# Altium schematic sheet TopLevel.SchDoc(TopLevel)
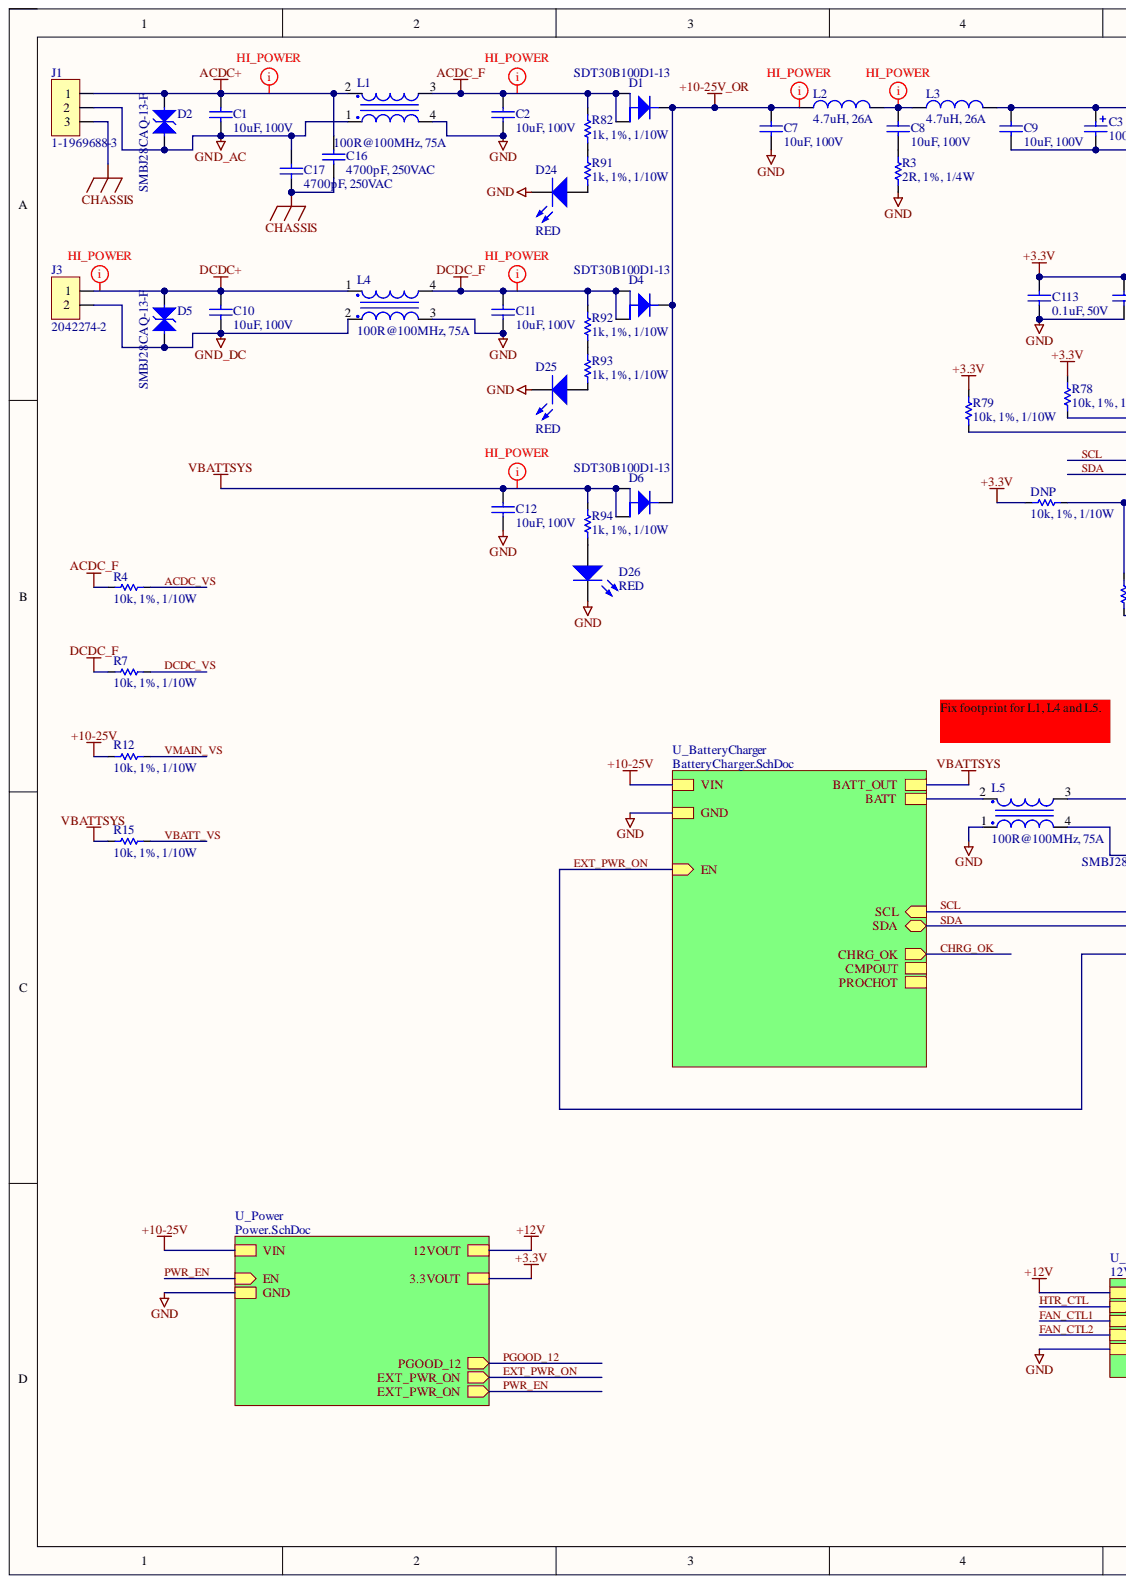
[diagram p0: TopLevel.SchDoc, left]
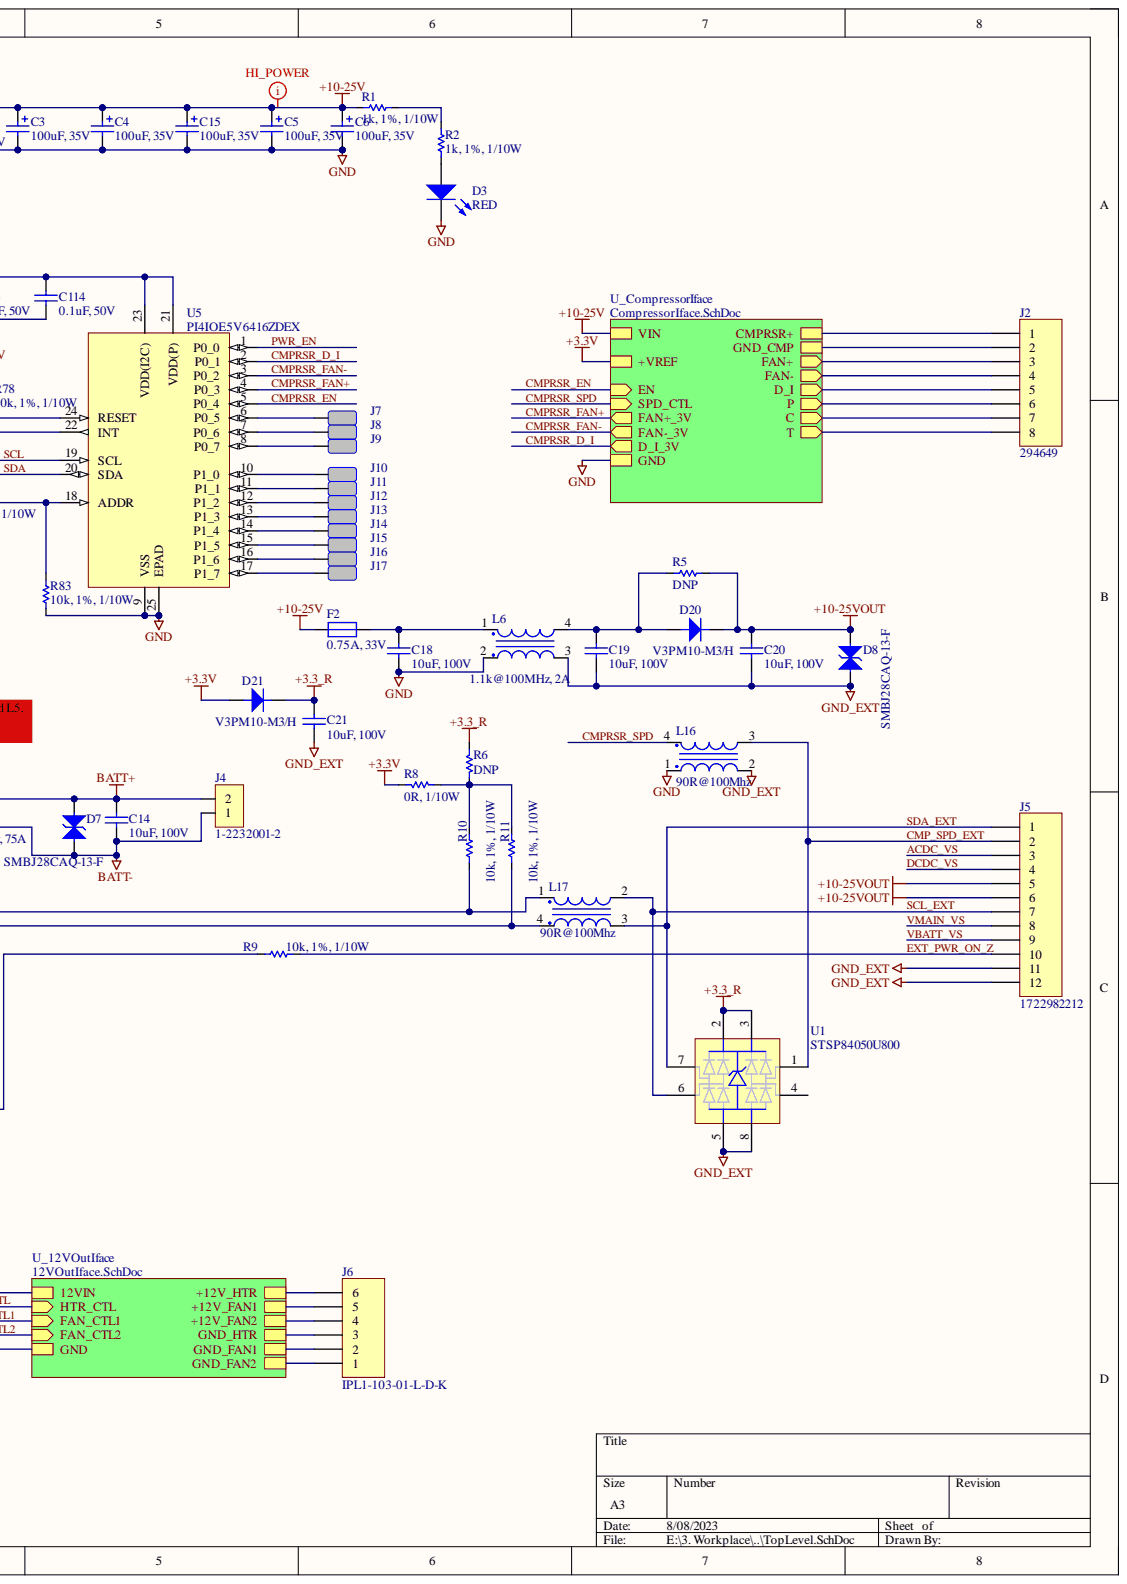
[diagram p1: TopLevel.SchDoc, right]

## Parts
C1  10uF, 100V  [1210]
C2  10uF, 100V  [1210]
C3  100uF, 35V  [CAPAE7866X80L]
C4  100uF, 35V  [CAPAE7866X80L]
C5  100uF, 35V  [CAPAE7866X80L]
C6  100uF, 35V  [CAPAE7866X80L]
C7  10uF, 100V  [1210]
C8  10uF, 100V  [1210]
C9  10uF, 100V  [1210]
C10  10uF, 100V  [1210]
C11  10uF, 100V  [1210]
C12  10uF, 100V  [1210]
C14  10uF, 100V  [1210]
C15  100uF, 35V  [CAPAE7866X80L]
C16  4700pF, 250VAC  [2220-2.5_CAP]
C17  4700pF, 250VAC  [2220-2.5_CAP]
C18  10uF, 100V  [1210]
C19  10uF, 100V  [1210]
C20  10uF, 100V  [1210]
C21  10uF, 100V  [1210]
C113  0.1uF, 50V  [0603]
C114  0.1uF, 50V  [0603]
D1  SDT30B100D1-13  [DPAK]
D2  SMBJ28CAQ-13-F  [SMB]
D3  RED  [0603_DIODE_K1A2]
D4  SDT30B100D1-13  [DPAK]
D5  SMBJ28CAQ-13-F  [SMB]
D6  SDT30B100D1-13  [DPAK]
D7  SMBJ28CAQ-13-F  [SMB]
D8  SMBJ28CAQ-13-F  [SMB]
D20  V3PM10-M3/H  [SMP]
D21  V3PM10-M3/H  [SMP]
D24  RED  [0603_DIODE_K1A2]
D25  RED  [0603_DIODE_K1A2]
D26  RED  [0603_DIODE_K1A2]
DNP  10k, 1%, 1/10W  [0603]
F2  0.75A, 33V  [1812_CAP]
J1  1-1969688-3  [CONN_TE_1-1969688-3]
J2  294649  [CONN_TE_294649]
J3  2042274-2  [CONN_TE_2042274-2]
J4  1-2232001-2  [CONN_TE_1-2232001-2]
J5  1722982212  [CONN_MOLEX_1722982212]
J6  IPL1-103-01-L-D-K  [CONN_SAMTEC_IPL1-103-XX-X-D]
J7  1.5x3.5  [PAD_15_35]  (value not drawn)  (no BOM)
J8  1.5x3.5  [PAD_15_35]  (value not drawn)  (no BOM)
J9  1.5x3.5  [PAD_15_35]  (value not drawn)  (no BOM)
J10  1.5x3.5  [PAD_15_35]  (value not drawn)  (no BOM)
J11  1.5x3.5  [PAD_15_35]  (value not drawn)  (no BOM)
J12  1.5x3.5  [PAD_15_35]  (value not drawn)  (no BOM)
J13  1.5x3.5  [PAD_15_35]  (value not drawn)  (no BOM)
J14  1.5x3.5  [PAD_15_35]  (value not drawn)  (no BOM)
J15  1.5x3.5  [PAD_15_35]  (value not drawn)  (no BOM)
J16  1.5x3.5  [PAD_15_35]  (value not drawn)  (no BOM)
J17  1.5x3.5  [PAD_15_35]  (value not drawn)  (no BOM)
L1  100R@100MHz, 75A  [CHOKE_TH_13.72x10.41x10.52_6.35x5.28_3.07x0.97]
L2  4.7uH, 26A  [INDM178169X70N]
L3  4.7uH, 26A  [INDM178169X70N]
L4  100R@100MHz, 75A  [CHOKE_TH_13.72x10.41x10.52_6.35x5.28_3.07x0.97]
L5  100R@100MHz, 75A  [CHOKE_TH_13.72x10.41x10.52_6.35x5.28_3.07x0.97]
L6  1.1k@100MHz, 2A  [PLCC440P435X370X235-4L]
L16  90R@100Mhz  [BOURNS_SRF2012]
L17  90R@100Mhz  [BOURNS_SRF2012]
R1  1k, 1%, 1/10W  [0603]
R2  1k, 1%, 1/10W  [0603]
R3  2R, 1%, 1/4W  [1206]
R4  10k, 1%, 1/10W  [0603]
R5  DNP  [0805]
R6  DNP  [0603]
R7  10k, 1%, 1/10W  [0603]
R8  0R, 1/10W  [0603]
R9  10k, 1%, 1/10W  [0603]
R10  10k, 1%, 1/10W  [0603]
R11  10k, 1%, 1/10W  [0603]
R12  10k, 1%, 1/10W  [0603]
R15  10k, 1%, 1/10W  [0603]
R78  10k, 1%, 1/10W  [0603]
R79  10k, 1%, 1/10W  [0603]
R82  1k, 1%, 1/10W  [0603]
R83  10k, 1%, 1/10W  [0603]
R91  1k, 1%, 1/10W  [0603]
R92  1k, 1%, 1/10W  [0603]
R93  1k, 1%, 1/10W  [0603]
R94  1k, 1%, 1/10W  [0603]
U1  STSP84050U800  [SOIC127P600X163-8N]
U5  PI4IOE5V6416ZDEX  [QFN50P400X400X90_HS-25L]

## Nets
+3.3_R: C21.2 D21.2 R6.2 U1.2 U1.3
+3.3V: C113.1 C114.1 D21.1 DNP.1 R8.1 R78.1 R79.1 U5.21(VDD(P)) U5.23(VDD(I2C))
+10-25V: C3.1 C4.1 C5.1 C6.1 C9.2 C15.1 F2.2 L3.2 R1.2 R12.2
+10-25V_OR: C7.2 D1.2 D4.2 D6.2 L2.1
+10-25VOUT: C20.2 D8.2 D20.2 J5.5 J5.6 R5.2
ACDC+: C1.2 C16.2 D2.2 J1.1 L1.2
ACDC_F: C2.2 D1.1 D1.3 L1.3 R4.2 R82.1
BATT+: C14.2 D7.2 J4.2 L5.3
BATT-: C14.1 D7.1 J4.1 L5.4
CHASSIS: C16.1 C17.1 J1.3
DCDC+: C10.2 D5.2 J3.1 L4.1
DCDC_F: C11.2 D4.1 D4.3 L4.4 R7.2 R92.1
GND: C2.1 C3.2 C4.2 C5.2 C6.2 C7.1 C9.1 C11.1 C12.1 C15.2 C18.1 C113.2 C114.2 D3.2 D24.2 D25.2 D26.2 L1.4 L4.3 L5.1 L6.2 L16.1 R3.1 R83.2 U5.9(VSS) U5.25(EPAD)
GND_AC: C1.1 C17.2 D2.1 J1.2 L1.1
GND_DC: C10.1 D5.1 J3.2 L4.2
GND_EXT: C19.1 C20.1 C21.1 D8.1 J5.11 J5.12 L6.3 L16.2 U1.5 U1.8
VBATTSYS: C12.2 D6.1 D6.3 R15.2 R94.1
~{PWR_EN}: U5.1(P0_0)
ACDC_VS: J5.3 R4.1
CMP_SPD_EXT: J5.2 L16.3 U1.1
CMPRSR_D_I: U5.2(P0_1)
CMPRSR_EN: U5.5(P0_4)
CMPRSR_FAN+: U5.4(P0_3)
CMPRSR_FAN-: U5.3(P0_2)
CMPRSR_SPD: L16.4
DCDC_VS: J5.4 R7.1
EXT_PWR_ON: R9.2
EXT_PWR_ON_Z: J5.10 R9.1
SCL: L17.1 R10.2 U5.19(SCL)
SCL_EXT: J5.7 L17.2 U1.6
SDA: L17.4 R11.2 U5.20(SDA)
SDA_EXT: J5.1 L17.3 U1.7
VBATT_VS: J5.9 R15.1
VMAIN_VS: J5.8 R12.1
NetC8_1: C8.1 R3.2
NetC8_2: C8.2 L2.2 L3.1
NetC18_2: C18.2 F2.1 L6.1
NetC19_2: C19.2 D20.1 L6.4 R5.1
NetD3_1: D3.1 R2.2
NetD24_1: D24.1 R91.2
NetD25_1: D25.1 R93.2
NetD26_1: D26.1 R94.2
NetDNP_2: DNP.2 R83.1 U5.18(ADDR)
NetJ2_1: J2.1
NetJ2_2: J2.2
NetJ2_3: J2.3
NetJ2_4: J2.4
NetJ2_5: J2.5
NetJ2_6: J2.6
NetJ2_7: J2.7
NetJ2_8: J2.8
NetJ6_1: J6.1
NetJ6_2: J6.2
NetJ6_3: J6.3
NetJ6_4: J6.4
NetJ6_5: J6.5
NetJ6_6: J6.6
NetJ7_1: J7.1 U5.6(P0_5)
NetJ8_1: J8.1 U5.7(P0_6)
NetJ9_1: J9.1 U5.8(P0_7)
NetJ10_1: J10.1 U5.10(P1_0)
NetJ11_1: J11.1 U5.11(P1_1)
NetJ12_1: J12.1 U5.12(P1_2)
NetJ13_1: J13.1 U5.13(P1_3)
NetJ14_1: J14.1 U5.14(P1_4)
NetJ15_1: J15.1 U5.15(P1_5)
NetJ16_1: J16.1 U5.16(P1_6)
NetJ17_1: J17.1 U5.17(P1_7)
NetL5_2: L5.2
NetR1_1: R1.1 R2.1
NetR6_1: R6.1 R8.2 R10.1 R11.1
NetR78_2: R78.2 U5.24(RESET)
NetR79_2: R79.2 U5.22(INT)
NetR82_2: R82.2 R91.1
NetR92_2: R92.2 R93.1
NetU1_4: U1.4
+12V:
~{PGOOD_12}:
~{PROCHOT}:
CHRG_OK:
CMPOUT:
FAN_CTL1:
FAN_CTL2:
HTR_CTL:

## Library data of the parts
C1 C2 C7-C12 C14 C18-C21 = NS00779: MLCC 10UF 100V X7S 1210; Alternatives: Generic; Database Table Name: AllParts; Type: C; Component Kind: Standard; Library Name: FullPartsDB.SVNDbLib; Part Number: NS00779; Supplier 1: Digi-Key; Supplier Part Number 1: 1276-CL32Y106KCVZNWECT-ND; Value: 10uF, 100V
C3-C6 C15 = NS00911: CAP ALUM 100UF 20% 35V SMD; Alternatives: Generic; Database Table Name: AllParts; Type: C; Component Kind: Standard; Library Name: FullPartsDB.SVNDbLib; Part Number: NS00911; Supplier 1: Digi-Key; Supplier Part Number 1: PCE5051CT-ND; Value: 100uF, 35V
C16 C17 = NS00768: CAP CER 4700PF 250VAC X7R 2220; Alternatives: Generic; Database Table Name: AllParts; Type: C; Component Kind: Standard; Library Name: FullPartsDB.SVNDbLib; Part Number: NS00768; Supplier 1: Digi-Key; Supplier Part Number 1: 2873-SCC2220X472K502TGCT-ND; Value: 4700pF, 250VAC
C113 C114 = NS00838: CAP CER 0.1UF 50V X7R 0603; Alternatives: Generic; Database Table Name: AllParts; Type: C; Component Kind: Standard; Library Name: FullPartsDB.SVNDbLib; Part Number: NS00838; Supplier 1: Digi-Key; Supplier Part Number 1: 1276-1935-1-ND; Value: 0.1uF, 50V
D1 D4 D6 = NS00785: DIODE SCHOTTKY 100V 30A TO252; Database Table Name: AllParts; Component Kind: Standard; ComponentLink1Description: Datasheet; Library Name: FullPartsDB.SVNDbLib; Part Number: NS00785; Supplier 1: Digi-Key; Supplier Part Number 1: SDT30B100D1-13DICT-ND; Type: Diode; Value: SDT30B100D1-13; ComponentLink1URL: H:\Doc\Diodes\SDT30B100D1.pdf
D2 D5 D7 D8 = NS00890: TVS DIODE 28VWM 45.4VC SMB; Database Table Name: AllParts; Component Kind: Standard; ComponentLink1Description: Datasheet; Library Name: FullPartsDB.SVNDbLib; Part Number: NS00890; Supplier 1: Digi-Key; Supplier Part Number 1: SMBJ28CAQ-13-FDICT-ND; Type: Diode; Value: SMBJ28CAQ-13-F; ComponentLink1URL: H:\Doc\Diodes\SMBJ6.5CAQ-SMBJ100CAQ.pdf
D3 D24-D26 = NS00945: LED RED CLEAR 1608 SMD; Database Table Name: AllParts; Type: IC; Component Kind: Standard; ComponentLink1Description: Datasheet; Library Name: FullPartsDB.SVNDbLib; Part Number: NS00945; Supplier 1: Digi-Key; Supplier Part Number 1: 3147-B1911USD-20D000114U1930CT-ND; Value: RED; ComponentLink1URL: H:\Doc\Harvatek\B1911USD-20D000114U1930.pdf
D20 D21 = NS00857: SCHOTTKY RECTIFIER 3A 100V SMP; Database Table Name: AllParts; Type: Diode; Component Kind: Standard; ComponentLink1Description: Datasheet; Library Name: FullPartsDB.SVNDbLib; Part Number: NS00857; Supplier 1: Digi-Key; Supplier Part Number 1: 112-V3PM10-M3/HCT-ND; Value: V3PM10-M3/H; ComponentLink1URL: H:\Doc\Vishay\v3pm10.pdf
DNP R4 R7 R9-R12 R15 R78 R79 R83 = NS00820: RES 10K OHM 1% 1/10W 0603; Alternatives: Generic; Database Table Name: AllParts; Component Kind: Standard; Library Name: FullPartsDB.SVNDbLib; Part Number: NS00820; Supplier 1: Digi-Key; Supplier Part Number 1: RMCF0603FT10K0CT-ND; Type: R; Value: 10k, 1%, 1/10W
F2 = NS00949: PTC RESET FUSE 33V 750MA 1812; Alternatives: Generic; Database Table Name: AllParts; Component Kind: Standard; Library Name: FullPartsDB.SVNDbLib; Part Number: NS00949; Supplier 1: Digi-Key; Supplier Part Number 1: 283-3153-1-ND; Type: Fuse; Value: 0.75A, 33V
J1 = NS00900: CONN HEADER VERT 3POS 6MM; Database Table Name: AllParts; Component Kind: Standard; ComponentLink1Description: Product Spec; ComponentLink2Description: Application Spec; ComponentLink3Description: Drawing; Library Name: FullPartsDB.SVNDbLib; Part Number: NS00900; Supplier 1: Digi-Key; Supplier Part Number 1: A120938-ND; Type: Connector; Value: 1-1969688-3; ComponentLink1URL: H:\Doc\Tyco\ENG_SS_108-32090_E.pdf; ComponentLink2URL: H:\Doc\Tyco\ENG_SS_114-32136_D.pdf; ComponentLink3URL: H:\Doc\Tyco\ENG_CD_1969688_C.pdf
J2 = NS00918: MAXIBR M 8POS VERT P SMT BK; Database Table Name: AllParts; Component Kind: Standard; ComponentLink1Description: Datasheet; Library Name: FullPartsDB.SVNDbLib; Part Number: NS00918; Supplier 1: Digi-Key; Supplier Part Number 1: 2684-294649CT-ND; Type: Connector; Value: 294649; ComponentLink1URL: H:\Doc\Tyco\ENG_DS_erni-maxibridge-2.54mm-connectors-ds_2206.pdf
J3 = NS00791: CONN HEADER 2POS PCB; Database Table Name: AllParts; Component Kind: Standard; ComponentLink1Description: Datasheet; ComponentLink2Description: Drawing; ComponentLink3Description: Application Specification; Library Name: FullPartsDB.SVNDbLib; Part Number: NS00791; Supplier 1: Digi-Key; Supplier Part Number 1: A105511CT-ND; Type: Connector; Value: 2042274-2; ComponentLink1URL: H:\Doc\Tyco\ENG_SS_108-19346_H1.pdf; ComponentLink2URL: H:\Doc\Tyco\ENG_CD_2042274_D.pdf; ComponentLink3URL: H:\Doc\Tyco\ENG_SS_114-19110_C.pdf
J4 = NS00788: CONN HEADER VERT 2POS 5MM; Database Table Name: AllParts; Component Kind: Standard; ComponentLink1Description: Datasheet; Library Name: FullPartsDB.SVNDbLib; Part Number: NS00788; Supplier 1: Digi-Key; Supplier Part Number 1: A117216-ND; Type: Connector; Value: 1-2232001-2; ComponentLink1URL: H:\Doc\Tyco\ENG_CD_1971845_B1.pdf
J5 = NS00894: CONN HEADER VERT 12POS 3.5MM; Database Table Name: AllParts; Component Kind: Standard; ComponentLink1Description: Datasheet; ComponentLink2Description: Application Specification; ComponentLink3Description: Drawing; Library Name: FullPartsDB.SVNDbLib; Part Number: NS00894; Supplier 1: Digi-Key; Supplier Part Number 1: WM11767-ND; Type: Connector; Value: 1722982212; ComponentLink1URL: H:\Doc\Molex\1722982212_PCB_HEADERS.pdf; ComponentLink2URL: H:\Doc\Molex\AS-172323-0001-001.pdf; ComponentLink3URL: H:\Doc\Molex\1722982212_sd.pdf
J6 = NS00897: CONN HEADER VERT 6POS 2.54MM; Database Table Name: AllParts; Component Kind: Standard; ComponentLink1Description: Datasheet; ComponentLink2Description: Dimensions; Library Name: FullPartsDB.SVNDbLib; Part Number: NS00897; Supplier 1: Digi-Key; Supplier Part Number 1: SAM9594-ND; Type: Connector; Value: IPL1-103-01-L-D-K; ComponentLink1URL: H:\Doc\Samtec\100_mini_mate.pdf; ComponentLink2URL: H:\Doc\Samtec\ipl1.pdf
J7-J17 = NS00465: PAD SOLDER 1.5x3.5MM; Database Table Name: AllParts; Library Name: FullPartsDB.SVNDbLib; Part Number: NS00465; Type: Mechanical; Value: 1.5x3.5
L1 L4 L5 = NS00942: CMC 75A 2LN 100 OHM TH; Database Table Name: AllParts; Type: Inductor; Component Kind: Standard; ComponentLink1Description: Datasheet; Library Name: FullPartsDB.SVNDbLib; Part Number: NS00942; Supplier 1: Digi-Key; Supplier Part Number 1: 240-2489-ND; Value: 100R@100MHz, 75A; ComponentLink1URL: H:\Doc\Laird\cm5441z101b-10-datasheet.pdf
L2 L3 = NS00854: FIXED IND 4.7UH 26A 5.5 MOHM SMD; Database Table Name: AllParts; Type: Inductor; Component Kind: Standard; ComponentLink1Description: Datasheet; Library Name: FullPartsDB.SVNDbLib; Part Number: NS00854; Supplier 1: Digi-Key; Supplier Part Number 1: SRP1770C-4R7MCT-ND; Value: 4.7uH, 26A; ComponentLink1URL: H:\Doc\Bourns\srp1770c.pdf
L6 = NS00952: CMC 2A 2LN 1.1K OHM SMD; Database Table Name: AllParts; Type: Inductor; Component Kind: Standard; ComponentLink1Description: Datasheet; Library Name: FullPartsDB.SVNDbLib; Part Number: NS00952; Supplier 1: Digi-Key; Supplier Part Number 1: 490-12641-1-ND; Value: 1.1k@100MHz, 2A; ComponentLink1URL: H:\Doc\Murata\EFLC0026.pdf
L16 L17 = NS00042: INDUCTOR COMMON MODE 90 OHM 25%; Database Table Name: AllParts; Component Kind: Standard; ComponentLink1Description: Datasheet; Library Name: FullPartsDB.SVNDbLib; Part Number: NS00042; Supplier 1: Digi-Key; Supplier Part Number 1: SRF2012-900YATR-ND; Type: Inductor; Value: 90R@100Mhz; ComponentLink1URL: H:\Doc\Bourns\SRF2012A.pdf
R1 R2 R82 R91-R94 = NS00882: RES 1K OHM 1% 1/10W 0603; Alternatives: Generic; Database Table Name: AllParts; Component Kind: Standard; Library Name: FullPartsDB.SVNDbLib; Part Number: NS00882; Supplier 1: Digi-Key; Supplier Part Number 1: RMCF0603FT1K00CT-ND; Type: R; Value: 1k, 1%, 1/10W
R3 = NS00946: RES 2 OHM 1% 1/4W 1206; Alternatives: Generic; Database Table Name: AllParts; Component Kind: Standard; Library Name: FullPartsDB.SVNDbLib; Part Number: NS00946; Supplier 1: Digi-Key; Supplier Part Number 1: 738-RMCF1206FT2R00CT-ND; Type: R; Value: 2R, 1%, 1/4W
R5 = NS00258: RES SMD 0.0 OHM JUMPER 1/2W 0805; Database Table Name: AllParts; Value: 0R; Component Kind: Standard; Library Name: FullPartsDB.SVNDbLib; Part Number: NS00258; Supplier 1: Digi-Key; Supplier Part Number 1: 36-5106CT-ND; Type: R
R6 = NS00876: RES 0 OHM JUMPER 1/10W 0603; Alternatives: Generic; Database Table Name: AllParts; Value: 0R, 1/10W; Component Kind: Standard; Library Name: FullPartsDB.SVNDbLib; Part Number: NS00876; Supplier 1: Digi-Key; Supplier Part Number 1: RMCF0603ZT0R00CT-ND; Type: R
R8 = NS00876: RES 0 OHM JUMPER 1/10W 0603; Alternatives: Generic; Database Table Name: AllParts; Component Kind: Standard; Library Name: FullPartsDB.SVNDbLib; Part Number: NS00876; Supplier 1: Digi-Key; Supplier Part Number 1: RMCF0603ZT0R00CT-ND; Type: R; Value: 0R, 1/10W
U1 = NS00913: TVS DIODE 5VWM 20VC 8-SOP; Database Table Name: AllParts; Component Kind: Standard; ComponentLink1Description: Datasheet; Library Name: FullPartsDB.SVNDbLib; Part Number: NS00913; Supplier 1: Digi-Key; Supplier Part Number 1: 283-STSP84050U800CT-ND; Type: IC; Value: STSP84050U800; ComponentLink1URL: H:\Doc\Eaton\eaton-stsp84xxxxxx-tvs-diode-array-esd-suppressor-data-sheet.pdf
U5 = NS00947: IC XPNDR 400KHZ I2C 24TQFN; Database Table Name: AllParts; Component Kind: Standard; ComponentLink1Description: Datasheet; Library Name: FullPartsDB.SVNDbLib; Part Number: NS00947; Supplier 1: Digi-Key; Supplier Part Number 1: PI4IOE5V6416ZDEXDICT-ND; Type: IC; Value: PI4IOE5V6416ZDEX; ComponentLink1URL: H:\Doc\Diodes\PI4IOE5V6416.pdf
